# Altium schematic sheet P03_POWER_SUPPLIES_2_OF.SchDoc(P03_POWER_SUPPLIES_2_OF)
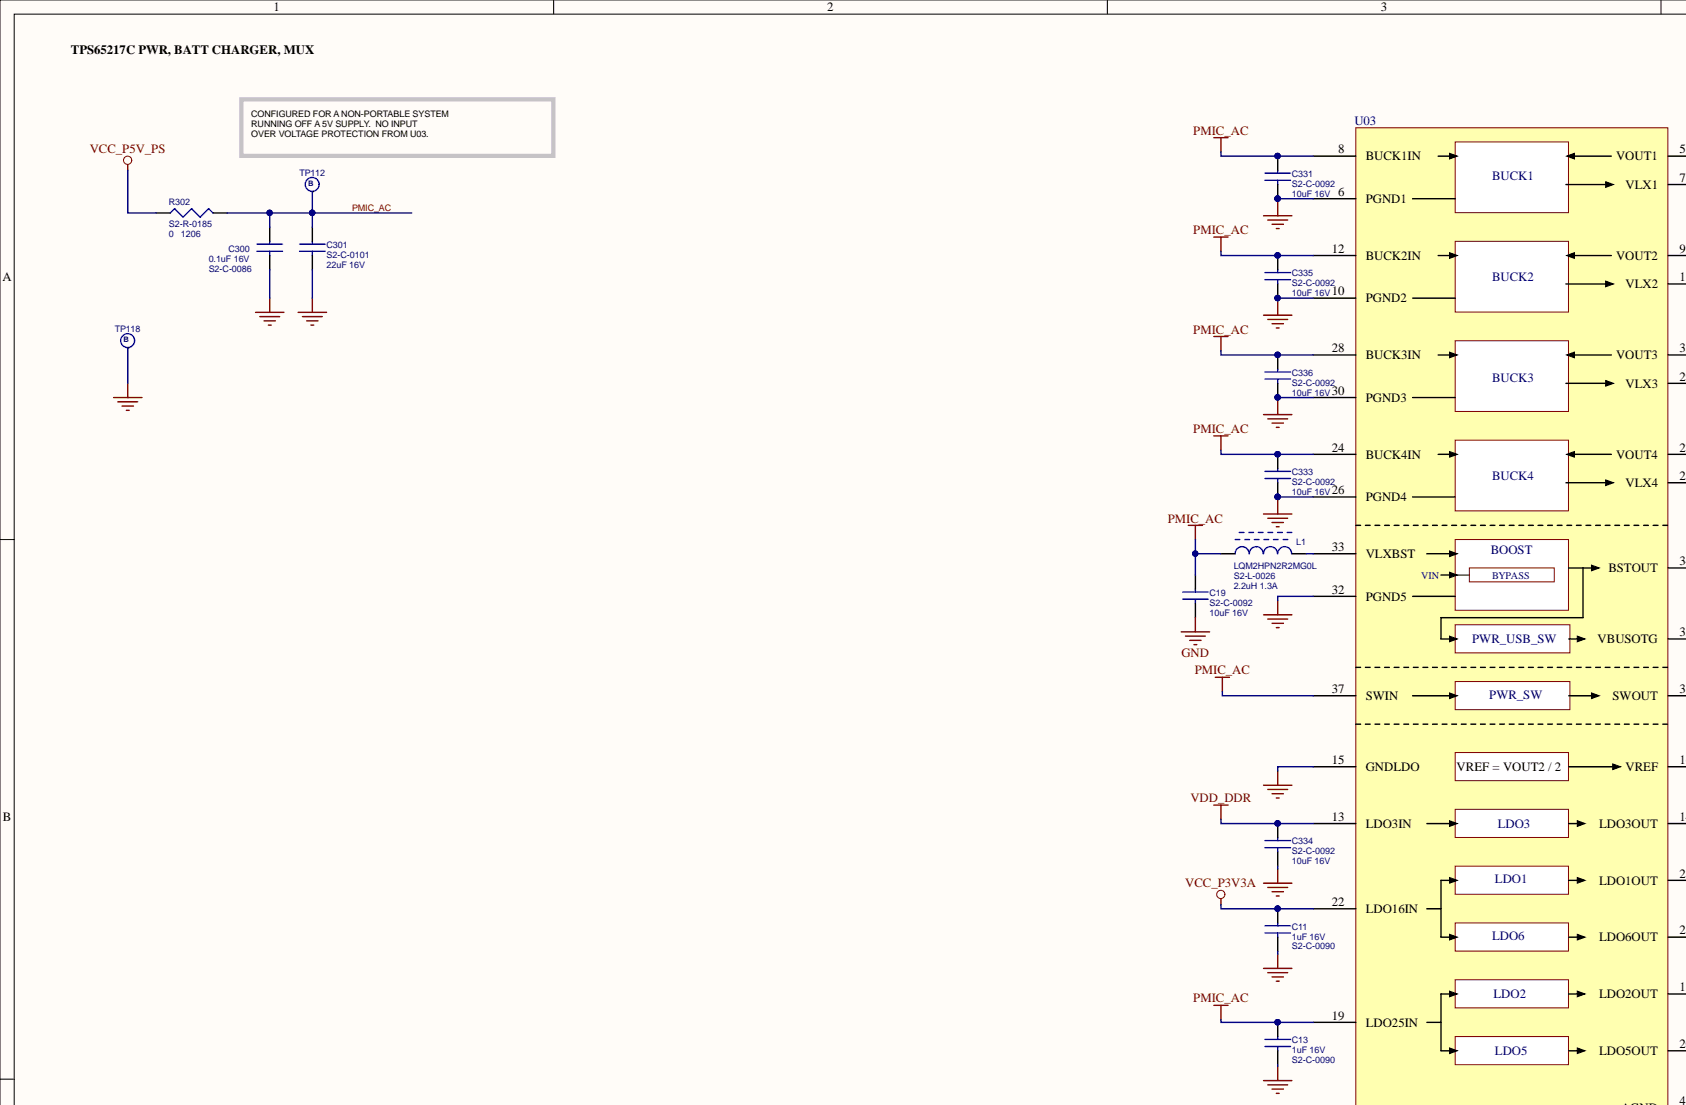
[diagram p0: P03_POWER_SUPPLIES_2_OF.SchDoc, top left]
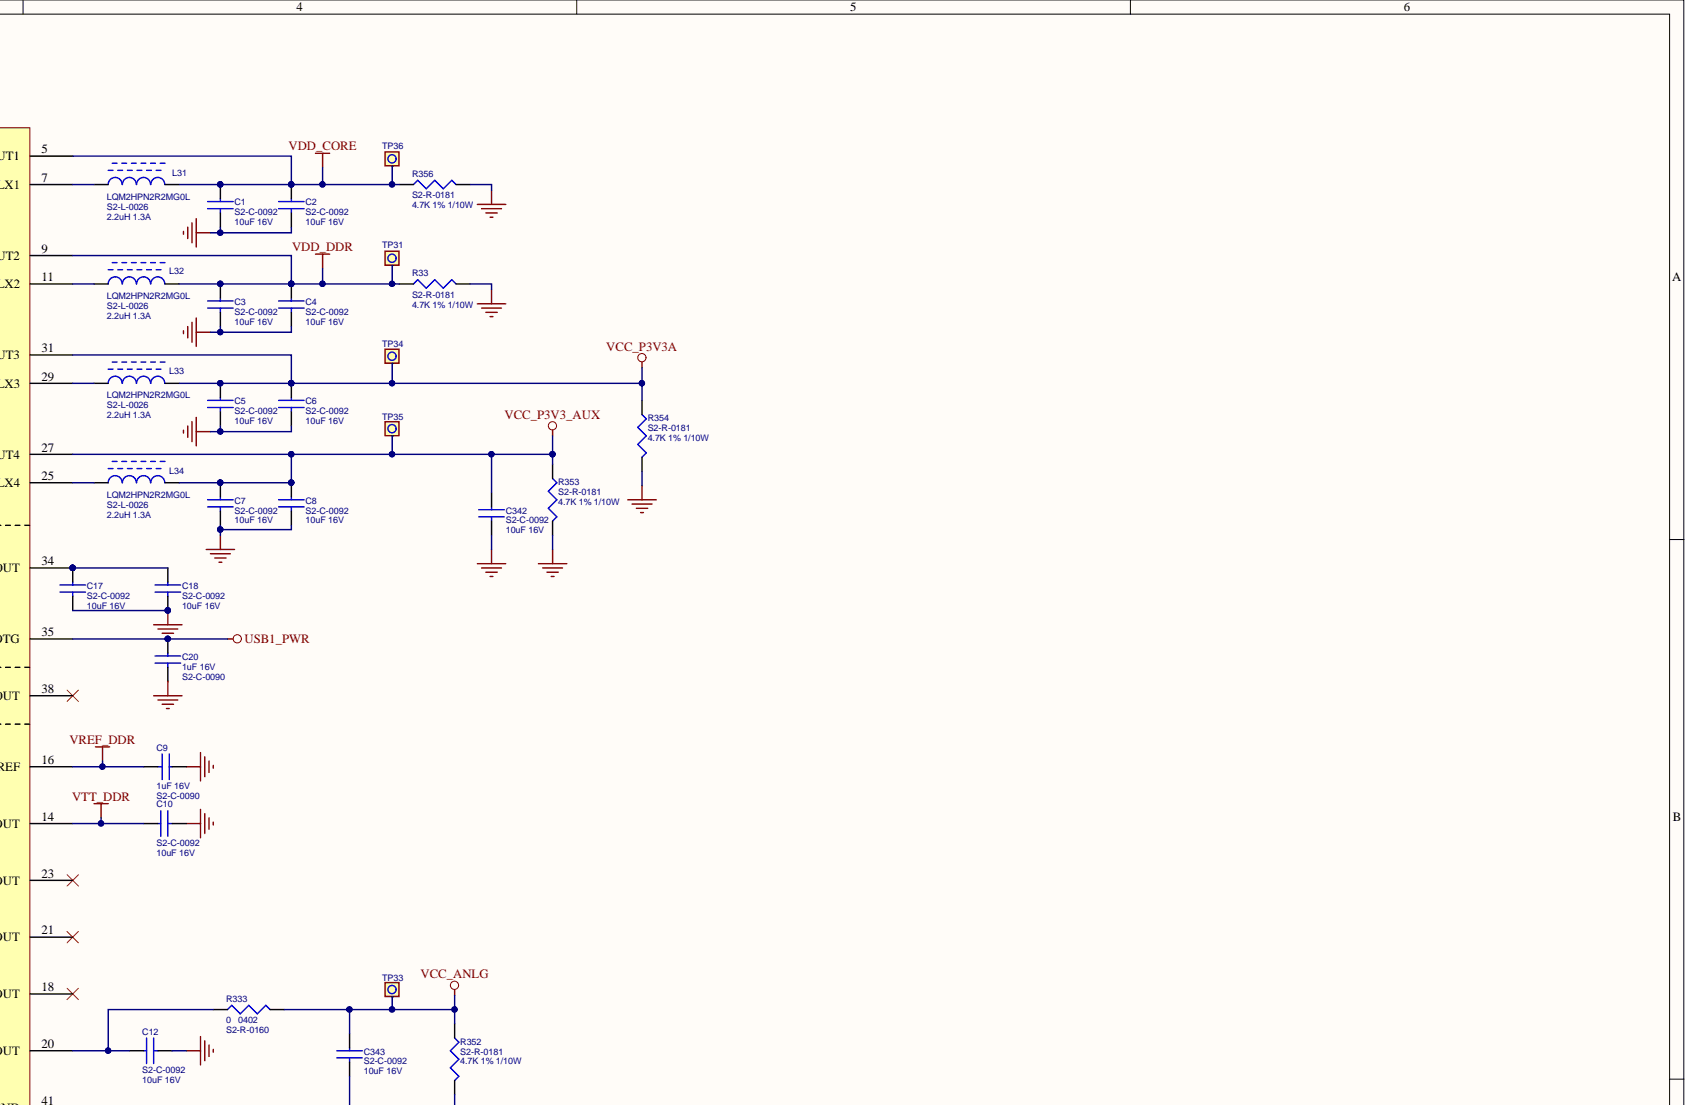
[diagram p1: P03_POWER_SUPPLIES_2_OF.SchDoc, top right]
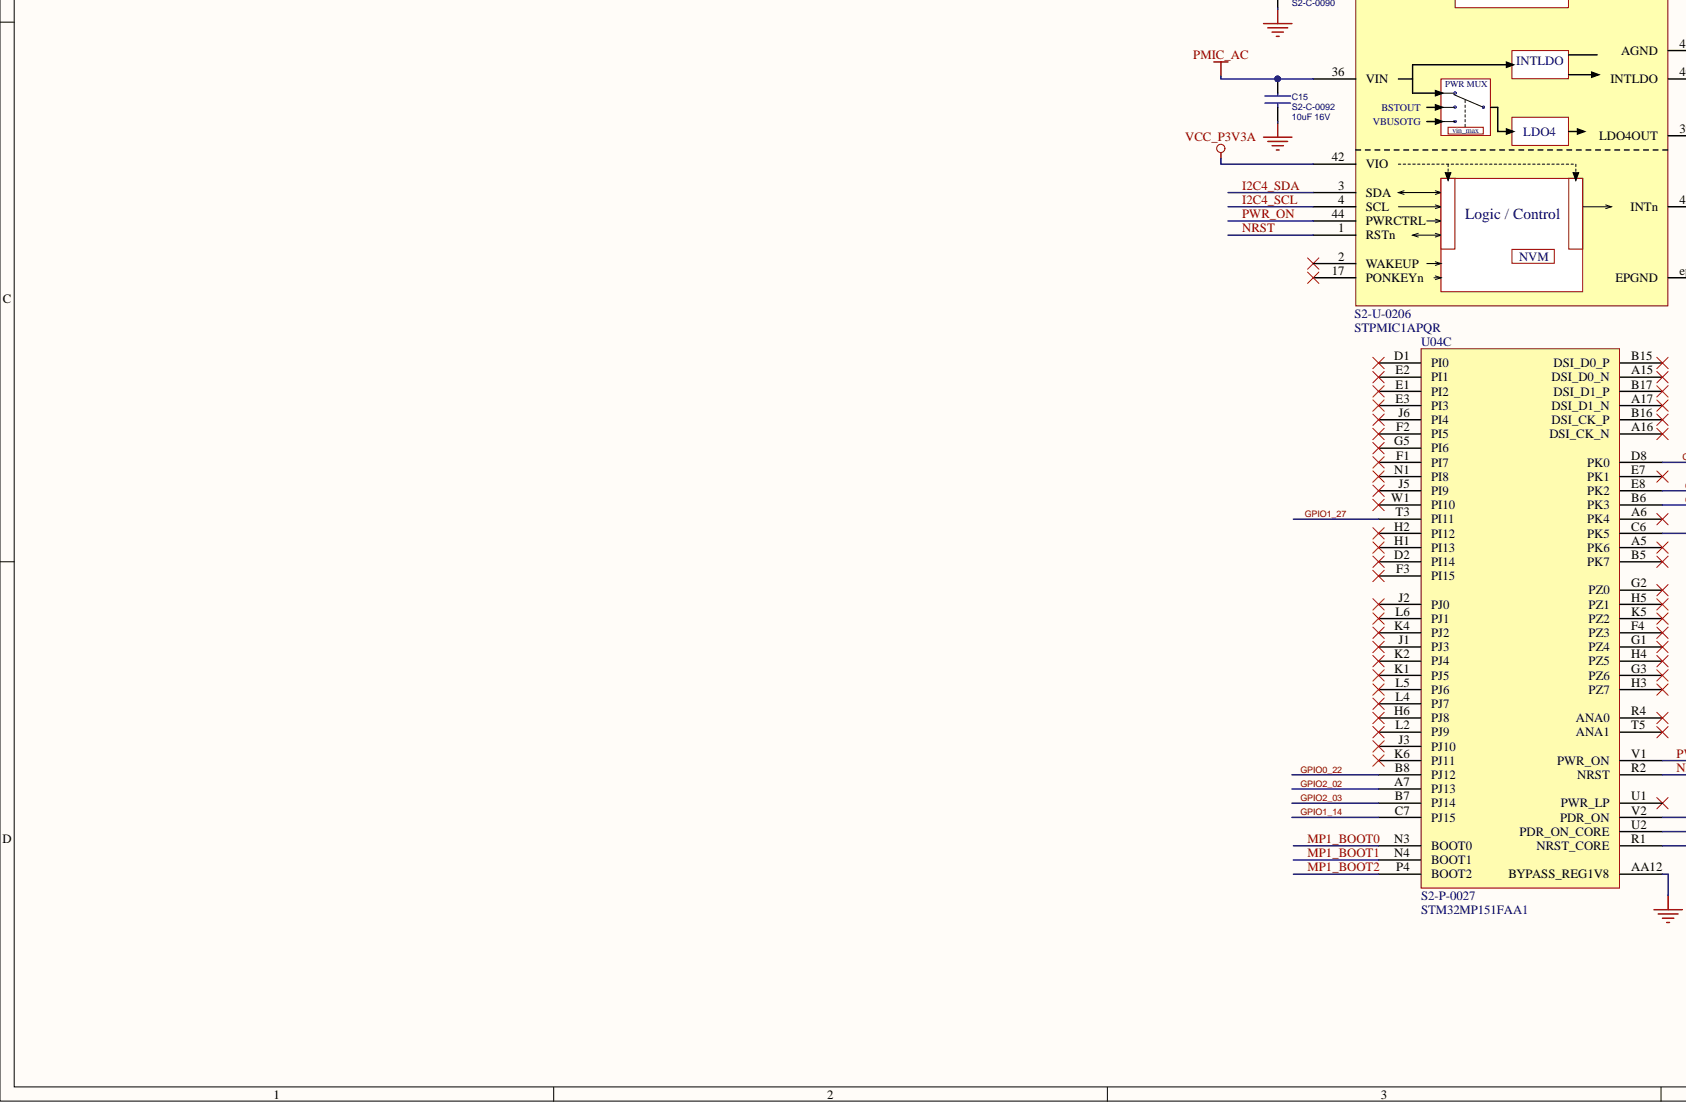
[diagram p2: P03_POWER_SUPPLIES_2_OF.SchDoc, bottom left]
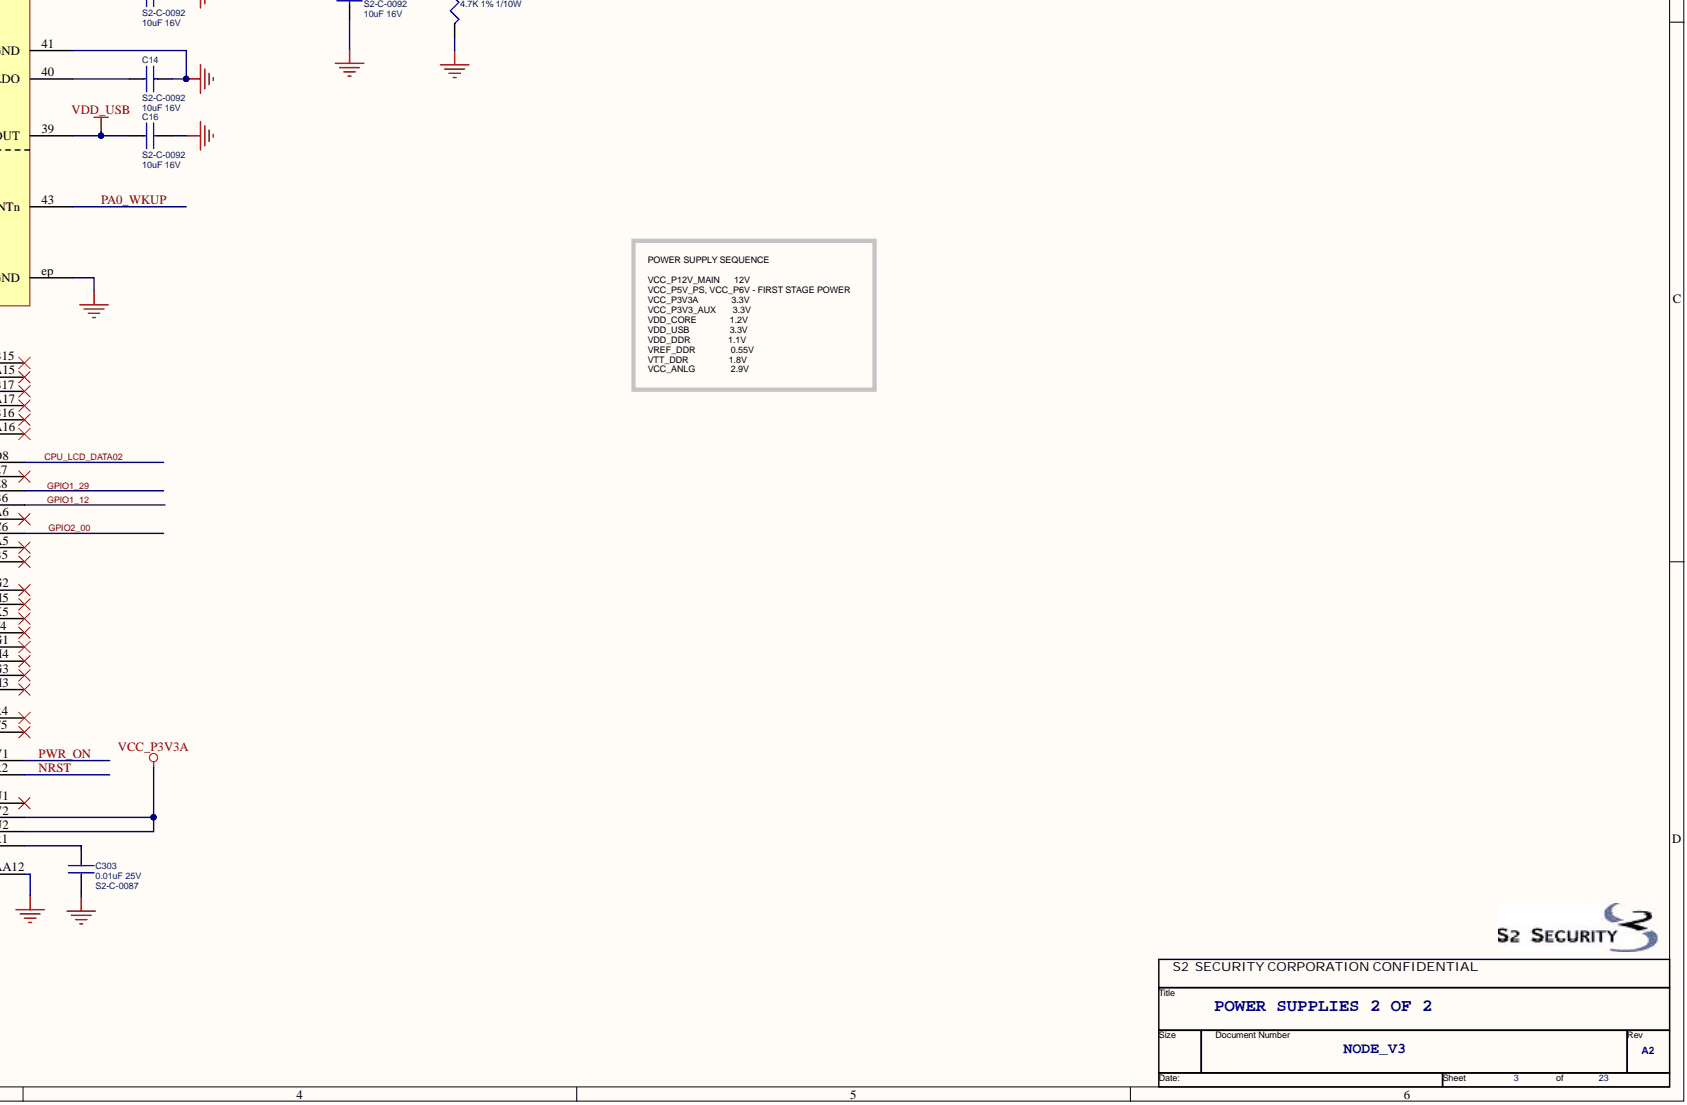
[diagram p3: P03_POWER_SUPPLIES_2_OF.SchDoc, bottom right]

## Parts
C1  S2_PN: S2-C-0092  [CAPC2012X145N]  (hidden comment: C_10U_16V_0805)
C2  S2_PN: S2-C-0092  DESC: 10uF 16V  [CAPC2012X145N]  (hidden comment: C_10U_16V_0805)
C3  S2_PN: S2-C-0092  [CAPC2012X145N]  (hidden comment: C_10U_16V_0805)
C4  S2_PN: S2-C-0092  DESC: 10uF 16V  [CAPC2012X145N]  (hidden comment: C_10U_16V_0805)
C5  S2_PN: S2-C-0092  [CAPC2012X145N]  (hidden comment: C_10U_16V_0805)
C6  S2_PN: S2-C-0092  DESC: 10uF 16V  [CAPC2012X145N]  (hidden comment: C_10U_16V_0805)
C7  S2_PN: S2-C-0092  [CAPC2012X145N]  (hidden comment: C_10U_16V_0805)
C8  S2_PN: S2-C-0092  DESC: 10uF 16V  [CAPC2012X145N]  (hidden comment: C_10U_16V_0805)
C9  DESC: 1uF 16V  [CAPC1005X55N]  (hidden comment: C_1U_16V_0402)
C10  S2_PN: S2-C-0092  DESC: 10uF 16V  [CAPC2012X145N]  (hidden comment: C_10U_16V_0805)
C11  S2_PN: S2-C-0090  DESC: 1uF 16V  [CAPC1005X55N]  (hidden comment: C_1U_16V_0402)
C12  S2_PN: S2-C-0092  DESC: 10uF 16V  [CAPC2012X145N]  (hidden comment: C_10U_16V_0805)
C13  S2_PN: S2-C-0090  DESC: 1uF 16V  [CAPC1005X55N]  (hidden comment: C_1U_16V_0402)
C14  S2_PN: S2-C-0092  [CAPC2012X145N]  (hidden comment: C_10U_16V_0805)
C15  S2_PN: S2-C-0092  [CAPC2012X145N]  (hidden comment: C_10U_16V_0805)
C16  S2_PN: S2-C-0092  DESC: 10uF 16V  [CAPC2012X145N]  (hidden comment: C_10U_16V_0805)
C17  S2_PN: S2-C-0092  DESC: 10uF 16V  [CAPC2012X145N]  (hidden comment: C_10U_16V_0805)
C18  S2_PN: S2-C-0092  DESC: 10uF 16V  [CAPC2012X145N]  (hidden comment: C_10U_16V_0805)
C19  S2_PN: S2-C-0092  DESC: 10uF 16V  [CAPC2012X145N]  (hidden comment: C_10U_16V_0805)
C20  S2_PN: S2-C-0090  DESC: 1uF 16V  [CAPC1005X55N]  (hidden comment: C_1U_16V_0402)
C300  S2_PN: S2-C-0086  DESC: 0.1uF 16V  [CAPC1005X55N]  (hidden comment: C_0U1_16V_0402)
C301  S2_PN: S2-C-0101  DESC: 22uF 16V  [CAPC2012X145N]  (hidden comment: C_22U_16V_0805)
C303  S2_PN: S2-C-0087  DESC: 0.01uF 25V  [CAPC1005X55N]  (hidden comment: C_0U01_25V_0402)
C331  S2_PN: S2-C-0092  [CAPC2012X145N]  (hidden comment: C_10U_16V_0805)
C333  S2_PN: S2-C-0092  [CAPC2012X145N]  (hidden comment: C_10U_16V_0805)
C334  S2_PN: S2-C-0092  [CAPC2012X145N]  (hidden comment: C_10U_16V_0805)
C335  S2_PN: S2-C-0092  [CAPC2012X145N]  (hidden comment: C_10U_16V_0805)
C336  S2_PN: S2-C-0092  [CAPC2012X145N]  (hidden comment: C_10U_16V_0805)
C342  S2_PN: S2-C-0092  [CAPC2012X145N]  (hidden comment: C_10U_16V_0805)
C343  S2_PN: S2-C-0092  DESC: 10uF 16V  [CAPC2012X145N]  (hidden comment: C_10U_16V_0805)
L1  S2_PN: S2-L-0026  DESC: LQM2HPN2R2MG0L  [INDC2520X100N]  (hidden comment: L_2U2_1A3_LQM2HPN2R2MG0L)
L31  S2_PN: S2-L-0026  DESC: LQM2HPN2R2MG0L  ATTR2: 2.2uH 1.3A  [INDC2520X100N]  (hidden comment: L_2U2_1A3_LQM2HPN2R2MG0L)
L32  S2_PN: S2-L-0026  DESC: LQM2HPN2R2MG0L  ATTR2: 2.2uH 1.3A  [INDC2520X100N]  (hidden comment: L_2U2_1A3_LQM2HPN2R2MG0L)
L33  S2_PN: S2-L-0026  DESC: LQM2HPN2R2MG0L  ATTR2: 2.2uH 1.3A  [INDC2520X100N]  (hidden comment: L_2U2_1A3_LQM2HPN2R2MG0L)
L34  S2_PN: S2-L-0026  DESC: LQM2HPN2R2MG0L  ATTR2: 2.2uH 1.3A  [INDC2520X100N]  (hidden comment: L_2U2_1A3_LQM2HPN2R2MG0L)
R33  S2_PN: S2-R-0181  DESC: 4.7K 1% 1/10W  [RESC1608X55N]  (hidden comment: R_4K7_0603)
R302  S2_PN: S2-R-0185  DESC: 0   1206  [RESC3216X60N]  (hidden comment: R_0_1206)
R333  S2_PN: S2-R-0160  DESC: 0   0402  [RESC1005X40N]  (hidden comment: R_0_0402)
R352  S2_PN: S2-R-0181  DESC: 4.7K 1% 1/10W  [RESC1608X55N]  (hidden comment: R_4K7_0603)
R353  S2_PN: S2-R-0181  DESC: 4.7K 1% 1/10W  [RESC1608X55N]  (hidden comment: R_4K7_0603)
R354  S2_PN: S2-R-0181  DESC: 4.7K 1% 1/10W  [RESC1608X55N]  (hidden comment: R_4K7_0603)
R356  S2_PN: S2-R-0181  DESC: 4.7K 1% 1/10W  [RESC1608X55N]  (hidden comment: R_4K7_0603)
TP31  TP_TH_TEST_POINT_SQUARE  [TEST-PT-TH-SQ]  (value not drawn)
TP33  TP_TH_TEST_POINT_SQUARE  [TEST-PT-TH-SQ]  (value not drawn)
TP34  TP_TH_TEST_POINT_SQUARE  [TEST-PT-TH-SQ]  (value not drawn)
TP35  TP_TH_TEST_POINT_SQUARE  [TEST-PT-TH-SQ]  (value not drawn)
TP36  TP_TH_TEST_POINT_SQUARE  [TEST-PT-TH-SQ]  (value not drawn)
TP112  TP_SMT_TEST_POINT_BOTTOM  [TP35_SMT]  (value not drawn)
TP118  TP_SMT_TEST_POINT_BOTTOM  [TP35_SMT]  (value not drawn)
U03  S2_PN: S2-U-0206  [ICC_WFQFN44-EPAD_5X6_P040]  (hidden comment: U_STPMIC1APQR)
U04  S2_PN: S2-P-0027  DESC: STM32MP151FAA1  [ICC_LFBGA448_18X18_STM32MP157BAA3_P080]  (hidden comment: U_STM32MP15xxAAx)  (part C)

## Nets
GND: C1.2 C2.2 C3.2 C4.2 C5.2 C6.2 C7.2 C8.2 C9.1 C10.2 C11.2 C12.2 C13.2 C14.2 C15.2 C16.2 C17.2 C18.2 C19.2 C20.2 C300.2 C301.2 C303.2 C331.2 C333.2 C334.2 C335.2 C336.2 C342.2 C343.2 R33.2 R352.2 R353.2 R354.2 R356.2 TP118.1 U03.6(PGND1) U03.10(PGND2) U03.15(GNDLDO) U03.26(PGND4) U03.30(PGND3) U03.32(PGND5) U03.41(AGND) U03.ep(EPGND) U04.AA12(BYPASS_REG1V8)
USB1_PWR: C20.1 U03.35(VBUSOTG)
VCC_ANLG: C343.1 R333.2 R352.1 TP33.1
VCC_P3V3_AUX: C7.1 C8.1 C342.1 L34.2 R353.1 TP35.1 U03.27(VOUT4)
VCC_P3V3A: C5.1 C6.1 C11.1 L33.2 R354.1 TP34.1 U03.22(LDO16IN) U03.31(VOUT3) U03.42(VIO) U04.U2(PDR_ON_CORE) U04.V2(PDR_ON)
VCC_P5V_PS: R302.1
VDD_CORE: C1.1 C2.1 L31.2 R356.1 TP36.1 U03.5(VOUT1)
VDD_DDR: C3.1 C4.1 C334.1 L32.2 R33.1 TP31.1 U03.9(VOUT2) U03.13(LDO3IN)
VDD_USB: C16.1 U03.39(LDO4OUT)
VREF_DDR: C9.2 U03.16(VREF)
VTT_DDR: C10.1 U03.14(LDO3OUT)
CPU_LCD_DATA02: U04.D8(PK0)
GPIO0_22: U04.B8(PJ12)
GPIO1_12: U04.B6(PK3)
GPIO1_14: U04.C7(PJ15)
GPIO1_27: U04.T3(PI11)
GPIO1_29: U04.E8(PK2)
GPIO2_00: U04.C6(PK5)
GPIO2_02: U04.A7(PJ13)
GPIO2_03: U04.B7(PJ14)
I2C4_SCL: U03.4(SCL)
I2C4_SDA: U03.3(SDA)
MP1_BOOT0: U04.N3(BOOT0)
MP1_BOOT1: U04.N4(BOOT1)
MP1_BOOT2: U04.P4(BOOT2)
NRST: U03.1(RSTn) U04.R2(NRST)
PA0_WKUP: U03.43(INTn)
PMIC_AC: C13.1 C15.1 C19.1 C300.1 C301.1 C331.1 C333.1 C335.1 C336.1 L1.1 R302.2 TP112.1 U03.8(BUCK1IN) U03.12(BUCK2IN) U03.19(LDO25IN) U03.24(BUCK4IN) U03.28(BUCK3IN) U03.36(VIN) U03.37(SWIN)
PWR_ON: U03.44(PWRCTRL) U04.V1(PWR_ON)
NetC12_1: C12.1 R333.1 U03.20(LDO5OUT)
NetC14_1: C14.1 U03.40(INTLDO)
NetC17_1: C17.1 C18.1 U03.34(BSTOUT)
NetC303_1: C303.1 U04.R1(NRST_CORE)
NetL1_2: L1.2 U03.33(VLXBST)
NetL31_1: L31.1 U03.7(VLX1)
NetL32_1: L32.1 U03.11(VLX2)
NetL33_1: L33.1 U03.29(VLX3)
NetL34_1: L34.1 U03.25(VLX4)

Not connected: U03 2(WAKEUP) 17(PONKEYn) 18(LDO2OUT) 21(LDO6OUT) 23(LDO1OUT) 38(SWOUT); U04 A5(PK6) A6(PK4) A15(DSI_D0_N) A16(DSI_CK_N) A17(DSI_D1_N) B5(PK7) B15(DSI_D0_P) B16(DSI_CK_P) B17(DSI_D1_P) D1(PI0) D2(PI14) E1(PI2) E2(PI1) E3(PI3) E7(PK1) F1(PI7) F2(PI5) F3(PI15) F4(PZ3) G1(PZ4) G2(PZ0) G3(PZ6) G5(PI6) H1(PI13) H2(PI12) H3(PZ7) H4(PZ5) H5(PZ1) H6(PJ8) J1(PJ3) J2(PJ0) J3(PJ10) J5(PI9) J6(PI4) K1(PJ5) K2(PJ4) K4(PJ2) K5(PZ2) K6(PJ11) L2(PJ9) L4(PJ7) L5(PJ6) L6(PJ1) N1(PI8) R4(ANA0) T5(ANA1) U1(PWR_LP) W1(PI10)

## Library data of the parts
C1 C3 C5 C7 C14 C15 C331 C333-C336 C342 = C_10U_16V_0805: PKG: CC0805IPC; DESC: 10uF 16V; ATTR: X5R 10%; Library Name: NODE_V2_M1.SCHLIB; Component Kind: Standard
C2 C4 C6 C8 C10 C12 C16-C19 C343 = C_10U_16V_0805: PKG: CC0805IPC; ATTR: X5R 10%; Library Name: NODE_V2_M1.SCHLIB; Component Kind: Standard
C9 = C_1U_16V_0402: S2_PN: S2-C-0090; PKG: CC0402IPC; ATTR: X5R 10%; Library Name: NODE_V2_M1.SCHLIB; Component Kind: Standard
C11 C13 C20 = C_1U_16V_0402: PKG: CC0402IPC; ATTR: X5R 10%; Library Name: NODE_V2_M1.SCHLIB; Component Kind: Standard
C300 = C_0U1_16V_0402: PKG: CC0402IPC; ATTR: X7R 10%; Library Name: NODE_V2_M1.SCHLIB; Component Kind: Standard
C301 = C_22U_16V_0805: PKG: CC0805IPC; ATTR: X5R 20%; Library Name: NODE_V2_M1.SCHLIB; Component Kind: Standard
C303 = C_0U01_25V_0402: PKG: CC0402IPC; ATTR: X7R 10%; Library Name: NODE_V2_M1.SCHLIB; Component Kind: Standard
L1 = L_2U2_1A3_LQM2HPN2R2MG0L: PKG: CC1008IPC; ATTR2: 2.2uH 1.3A; ATTR: INDUCTOR 2.2uH 20% 0.08ohm 1.3A; Library Name: NODE_V2_M1.SCHLIB; Component Kind: Standard
L31-L34 = L_2U2_1A3_LQM2HPN2R2MG0L: PKG: CC1008IPC; ATTR: INDUCTOR 2.2uH 20% 0.08ohm 1.3A; Library Name: NODE_V2_M1.SCHLIB; Component Kind: Standard
R33 R352-R354 R356 = R_4K7_0603: PKG: CC0603IPC; ATTR: RES 4.7K OHM 1/10W 1% 0603; Library Name: NODE_V2_M1.SCHLIB; Component Kind: Standard
R302 = R_0_1206: PKG: CC1206IPC; ATTR: RES 0 OHM 1/4W 0.020MAX 1206 3.5A; Library Name: NODE_V2_M1.SCHLIB; DNP: DNP; Component Kind: Standard
R333 = R_0_0402: PKG: CC0402IPC; ATTR: 1/16W  50mohm MAX; Library Name: NODE_V2_M1.SCHLIB; Component Kind: Standard
TP31 TP33-TP36 = TP_TH_TEST_POINT_SQUARE: S2_PN: S2-TP-0004; PKG: TEST-PT-TH; DESC: TEST POINT; ATTR: THRU HOLE TEST POINT; Library Name: NODE_V2_M1.SCHLIB; DNP: DNP; Component Kind: Standard
TP112 TP118 = TP_SMT_TEST_POINT_BOTTOM: S2_PN: S2-TP-0003; PKG: TEST-PT-TPB; DESC: TEST POINT; ATTR: SMT BOTTOM SIDE TEST POINT; Library Name: NODE_V2_M1.SCHLIB; DNP: DNP; Component Kind: Standard
U03 = U_STPMIC1APQR: ST PMIC:  4 step-down + 1 advanced boost SMPS, 6 LDOs, 2 pwr switches, DDR Vref, NVM option 'A' for IO@3.3V; VAR: IC; PKG: WFQFN_44L; ATTR: Power Management Unit 2.8V to 5.5V 44-Pin WFQFN EP T/R; Library Name: NODE_V2_M1.SCHLIB; DESC: STPMIC1APQR; ComponentLink1URL: http://tunmcdsvn.tun.st.com/Component_Datasheet_Library/Trunk/IC/STM_PMIC_LuftBurg_5x6_44L_20_01_2016; ComponentLink1Description: Datasheet; Component Kind: Standard
U04 = (no symbol name): MPU LFBGA18x18; ATTR: ARM Cortex-A7 Microprocessor IC STM32MP1 1 Core, 32-Bit 209MHz, 800MHz 448-LFBGA (18x18); PKG: LFBGA18x18; Library Name: NODE_V2_M1.SCHLIB; Component Kind: Standard
